# Altium schematic sheet Power Supply.SchDoc("Power Supply")
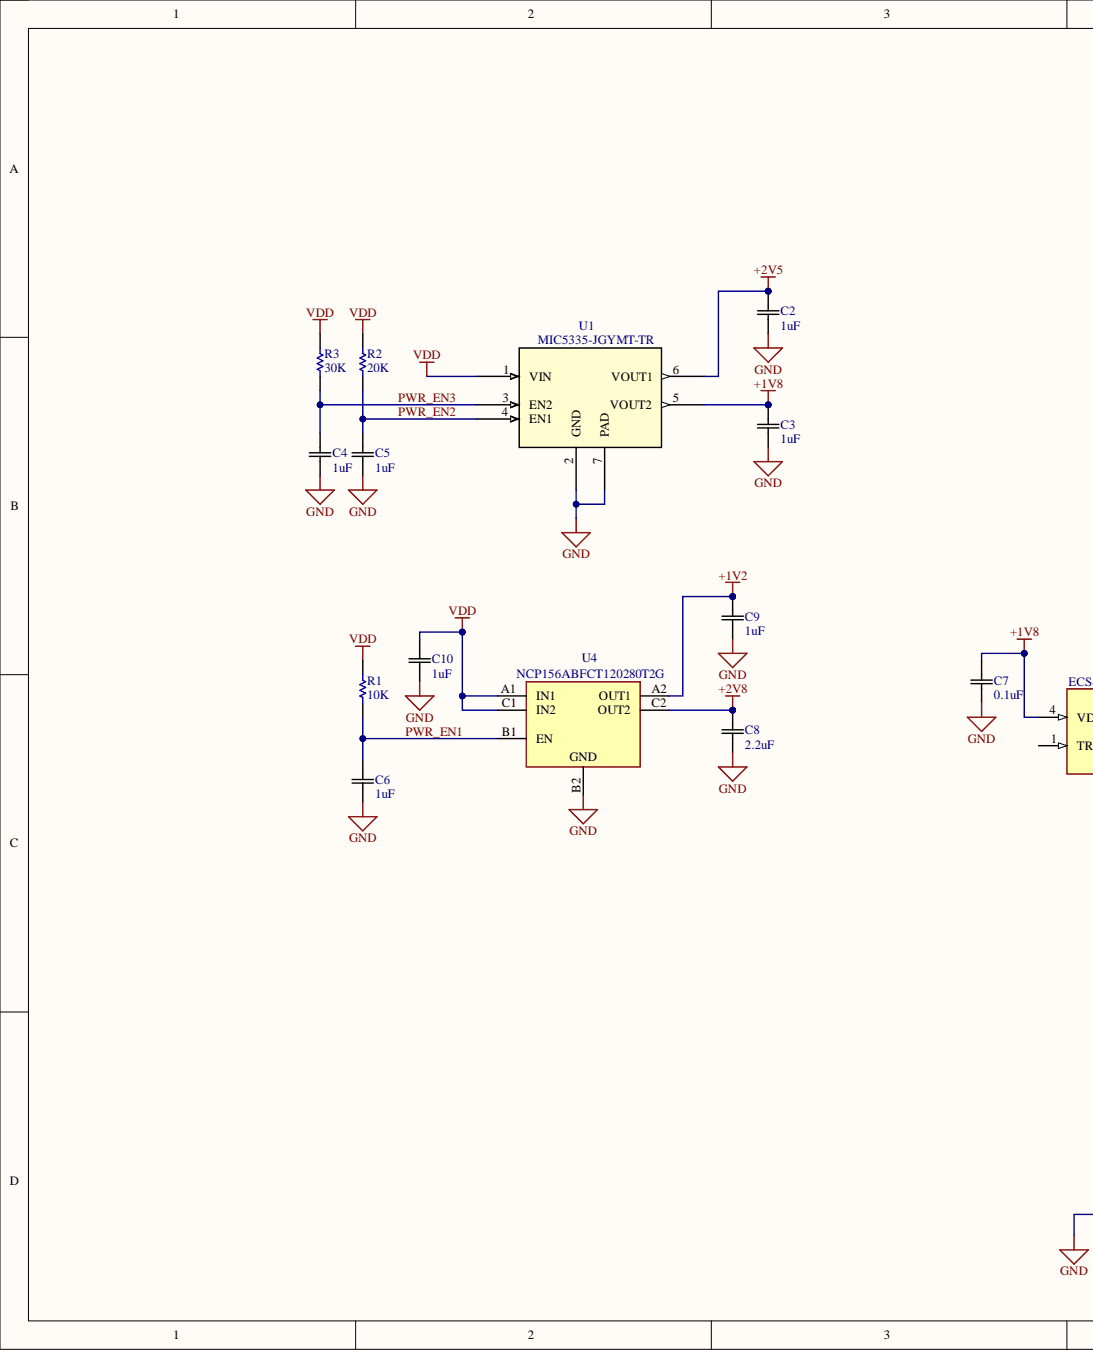
[diagram p0: Power Supply.SchDoc, left]
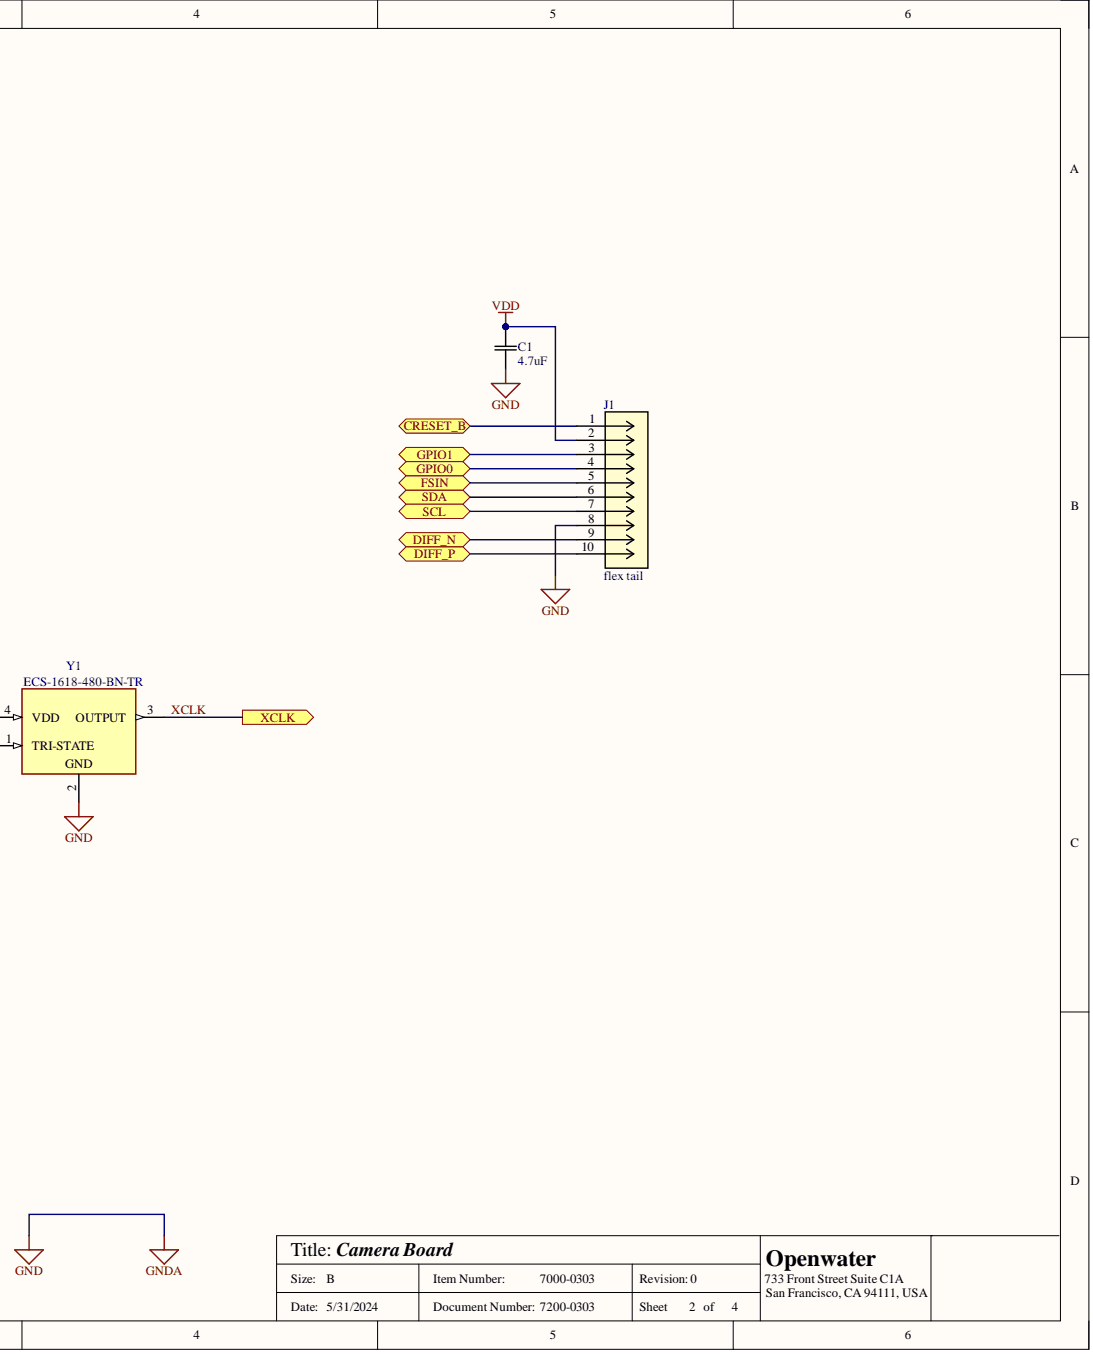
[diagram p1: Power Supply.SchDoc, right]

## Parts
C1  4.7uF
C2  1uF
C3  1uF
C4  1uF
C5  1uF
C6  1uF
C7  0.1uF
C8  2.2uF
C9  1uF
C10  1uF
J1  flex tail
R1  10K
R2  20K
R3  30K
U1  MIC5335-JGYMT-TR
U4  NCP156ABFCT120280T2G
Y1  ECS-1618-480-BN-TR

## Ports
CRESET_B, DIFF_N, DIFF_P, FSIN, GPIO0, GPIO1, SCL, SDA, XCLK

## Nets
+1V2: C9.2 U4.A2(OUT1)
+1V8: C3.2 C7.2 U1.5(VOUT2) Y1.4(VDD)
+2V5: C2.2 U1.6(VOUT1)
+2V8: C8.2 U4.C2(OUT2)
GND: C1.1 C2.1 C3.1 C4.1 C5.1 C6.1 C7.1 C8.1 C9.1 C10.1 J1.8 U1.2(GND) U1.7(PAD) U4.B2 Y1.2
VDD: C1.2 C10.2 J1.2 R1.2 R2.2 R3.2 U1.1(VIN) U4.A1(IN1) U4.C1(IN2)
CRESET_B: J1.1 ; port CRESET_B
DIFF_N: J1.9 ; port DIFF_N
DIFF_P: J1.10 ; port DIFF_P
FSIN: J1.5 ; port FSIN
GPIO0: J1.4 ; port GPIO0
GPIO1: J1.3 ; port GPIO1
PWR_EN1: C6.2 R1.1 U4.B1(EN)
PWR_EN2: C5.2 R2.1 U1.4(EN1)
PWR_EN3: C4.2 R3.1 U1.3(EN2)
SCL: J1.7 ; port SCL
SDA: J1.6 ; port SDA
XCLK: Y1.3(OUTPUT) ; port XCLK

Not connected: Y1 1(TRI-STATE)

## Library data of the parts
C1 = GRM035R60J475ME15D: Capacitor,4.7µF ±10% 6.3V Ceramic Capacitor X5R 0201 (0603 Metric); Mfr_Name: Yageo; Component Kind: Standard; Library Name: 7000-0xxx Camera PCA Rev 0.SCHLIB
C2-C6 C9 C10 = CC0201KRX5R5BB105: Capacitor,1 µF ±10% 6.3V Ceramic Capacitor X5R 0201 (0603 Metric); Mfr_Name: Yageo; Component Kind: Standard; Library Name: 7000-0xxx Camera PCA Rev 0.SCHLIB
C7 = GRM033R60J104KE19D: CAP,0.1µF ±10% 6.3V Ceramic Capacitor X5R 0201 (0603 Metric); Mfr_Name: Yageo; Component Kind: Standard; Library Name: 7000-0xxx Camera PCA Rev 0.SCHLIB
C8 = GRM033R60J225ME47D: CAP,2.2µF ±10% 6.3V Ceramic Capacitor X5R 0201 (0603 Metric); Mfr_Name: Yageo; Component Kind: Standard; Library Name: 7000-0xxx Camera PCA Rev 0.SCHLIB
J1 = flex tail: Connector,10 Position FFC, FPC Connector Contacts, Bottom 0.020" (0.50mm) Surface Mount, Right Angle; Mfr_Name: Hirose; Component Kind: Standard; Library Name: 7000-0303 Camera PCA Rev 0.SCHLIB; RefDes: RefDes; Type: Type
R1 = CRCW020110K0FNED: RES, 10 kOhms Â±1% 0.05W, 1/20W Chip Resistor 0201 _0603 Metric_ Thick Film; MF: Vishay Dale; MP: CRCW0201100KFNED; Availability: Unavailable; Component Kind: Standard; Library Name: 7000-0xxx Camera PCA Rev 0.SCHLIB; Package: 0603 Vishay; Price: None; SnapEDA_Link: https://www.snapeda.com/parts/CRCW0201100KFNED/Vishay%20Dale/view-part/3656241/?ref=snap
R2 = CRCW020120K0FKED: RES, 20 kOhms Â±1% 0.05W, 1/20W Chip Resistor 0201 _0603 Metric_ Thick Film; MF: Vishay Dale; MP: CRCW0201100KFNED; Availability: Unavailable; Component Kind: Standard; Library Name: 7000-0xxx Camera PCA Rev 0.SCHLIB; Package: 0603 Vishay; Price: None; SnapEDA_Link: https://www.snapeda.com/parts/CRCW0201100KFNED/Vishay%20Dale/view-part/3656241/?ref=snap
R3 = CRCW020130K0FKED: RES, 30 kOhms Â±1% 0.05W, 1/20W Chip Resistor 0201 _0603 Metric_ Thick Film; MF: Vishay Dale; MP: CRCW0201100KFNED; Availability: Unavailable; Component Kind: Standard; Library Name: 7000-0xxx Camera PCA Rev 0.SCHLIB; Package: 0603 Vishay; Price: None; SnapEDA_Link: https://www.snapeda.com/parts/CRCW0201100KFNED/Vishay%20Dale/view-part/3656241/?ref=snap
U1 = MIC5335-JGYMT-TR: IC, Linear Voltage Regulator IC Positive Fixed 2 Output 300mA, 300mA 6-TMLF® (1.6x1.6); Mfr_Name: Microchip; Component Kind: Standard; RefDes: RefDes; Type: Type; Library Name: 7000-0291 Laser Safety PCA Rev 0.SCHLIB
U4 = NCP156ABFCT120280T2G: IC, LDO Voltage Regulators DUAL 500 MA / 250 M A LDO; Manufacturer_Name: Analog Devices; Manufacturer_Part_Number: LT3094EMSE#PBF; Arrow Part Number: LT3094EMSE#PBF; Arrow Price/Stock: https://www.arrow.com/en/products/lt3094emsepbf/analog-devices?region=nac; Component Kind: Standard; Datasheet Link: https://www.analog.com/media/en/technical-documentation/data-sheets/LT3094.pdf; Height: 1.1mm; Library Name: 7000-0xxx Camera PCA Rev 0.SCHLIB; Mouser Part Number: 584-LT3094EMSE#PBF; Mouser Price/Stock: https://www.mouser.co.uk/ProductDetail/Analog-Devices/LT3094EMSEPBF?qs=qSfuJ%252Bfl%2Fd6MpUgXTyHQpQ%3D%3D
Y1 = ECS-1633-480-BN-TR: 48MHz XO (Standard) CMOS Oscillator 1.8V Enable/Disable 4-SMD, No Lead; MF: ECS Inc.; Component Kind: Standard; Digi-Key_Part_Number: XC1931CT-ND; DigiKey-Purchase-URL: https://snapeda.com/shop?store=DigiKey&id=2455313; Library Name: 7000-0xxx Camera PCA Rev 0.SCHLIB; MP: ECS-1633-480-BN-TR; Package: SMD-4 ECS International; SnapEDA_Link: https://www.snapeda.com/parts/ECS-1633-480-BN-TR/ECS%20Inc./view-part/2455313/?ref=snap
